# Altium schematic sheet Stage3A_Linear_Regulator_controller.SchDoc
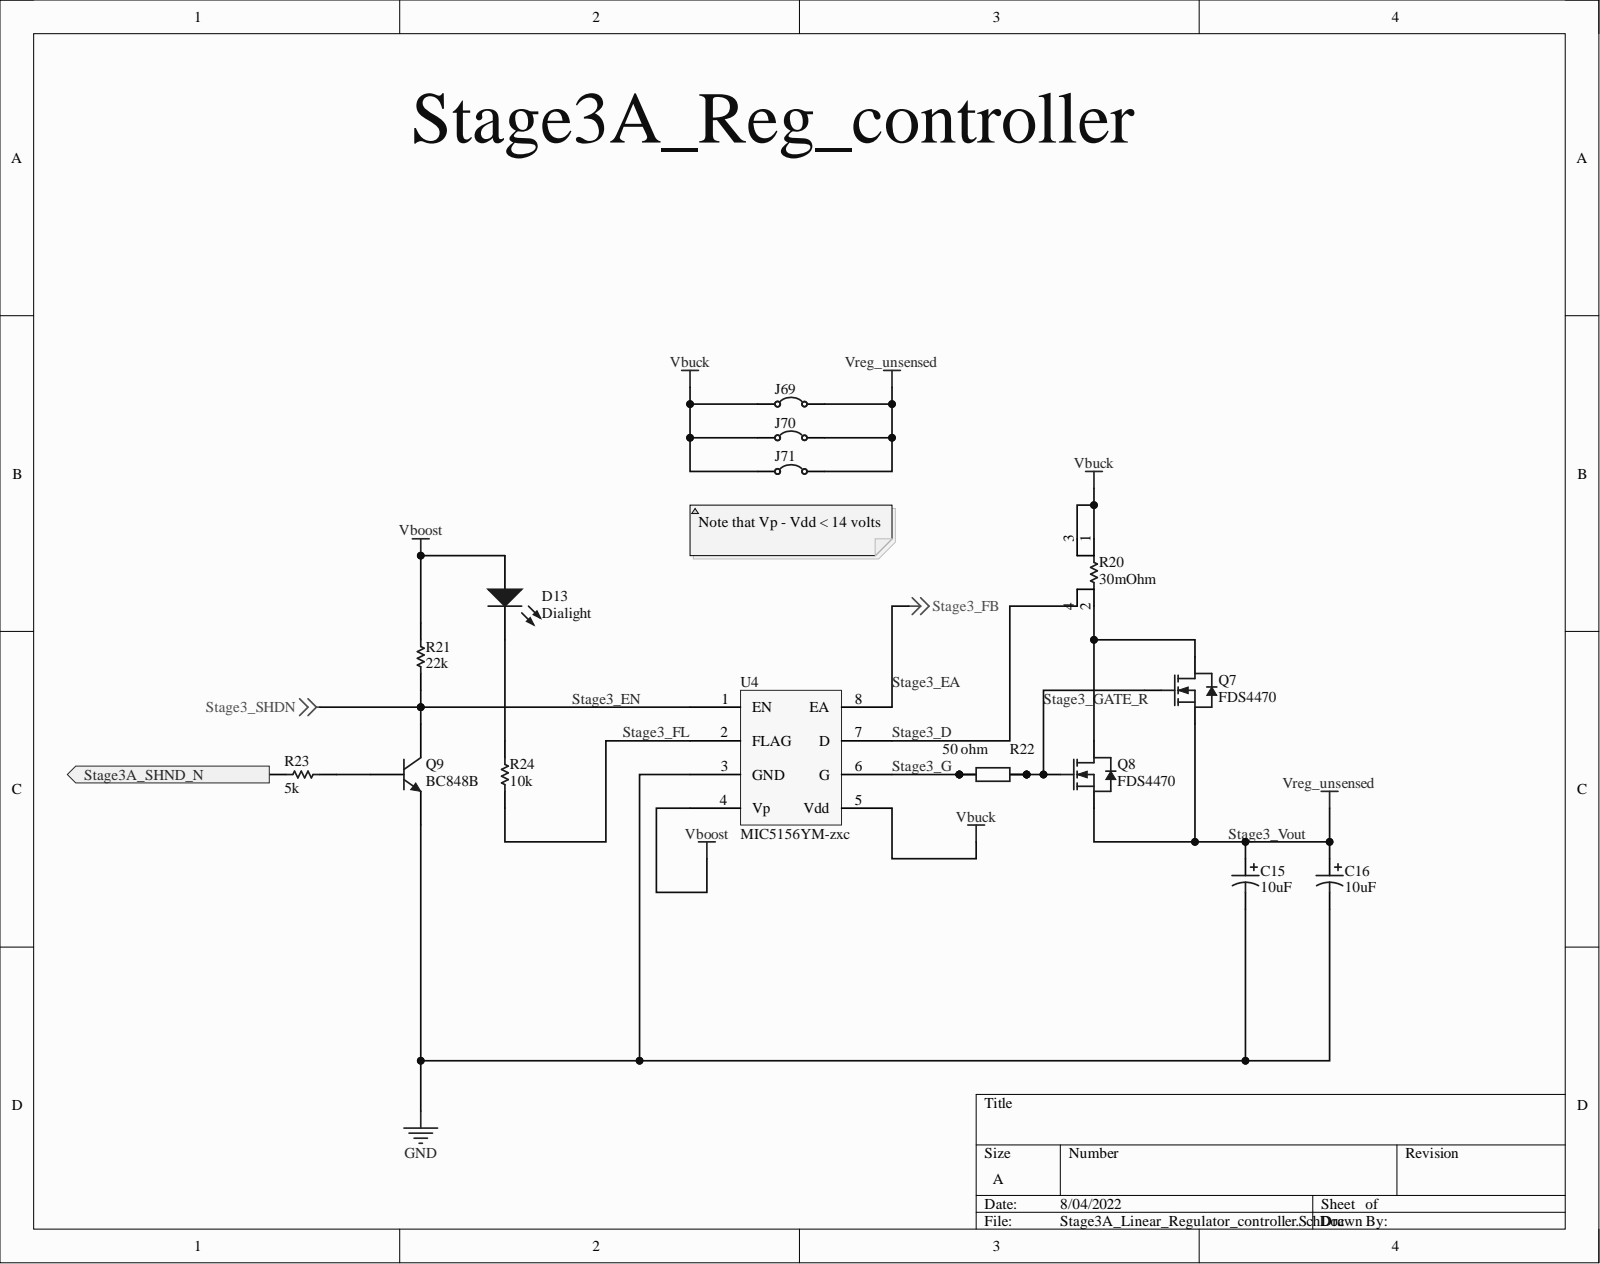
[diagram p0: Stage3A_Linear_Regulator_controller.SchDoc]

## Parts
C15  10uF  [PCB-45uljczbzgwzo9m7ql45-1]
C16  10uF  [PCB-45uljczbzgwzo9m7ql45-1]
D13  Dialight  [PCB-9ifma0oh53wy532w7hco-2]
J69  Harwin S1731-46R  [PCB-70dil207ibnp3ku15xhm-1]  (value not drawn)
J70  Harwin S1731-46R  [PCB-70dil207ibnp3ku15xhm-1]  (value not drawn)
J71  Harwin S1731-46R  [PCB-70dil207ibnp3ku15xhm-1]  (value not drawn)
Q7  FDS4470  [PCB-vam1no1ldi8601k0q85a-1]
Q8  FDS4470  [PCB-vam1no1ldi8601k0q85a-1]
Q9  BC848B  [PCB-hbuntr9r1m1073lqpw4t-1]
R20  30mOhm  [PCB-e4z1ou8eehx9zfei5nig-1]
R21  22k  [PCB-0002-00354-1]
R22  50 ohm  [PCB-7mdwqy8yim8csor4mvd5-1]
R23  5k  [PCB-uip3f7nxj24hdkeeu2m5-1]
R24  10k  [PCB-s0mv190h6t9hazm0rgl3-1]
U4  MIC5156YM-zxc  [D0008A_L]

## Ports
Stage3A_SHND_N

## Nets
GND: C15.2 C16.2 Q9.3 U4.3(GND)
Vboost: D13.2 R21.2 U4.4(Vp)
Vbuck: J69.1 J70.1 J71.1 R20.1 R20.3 U4.5(Vdd)
Stage3_D: R20.4 U4.7(D)
Stage3_EA: U4.8(EA)
Stage3_EN: Q9.1 R21.1 U4.1(EN)
Stage3_FL: R24.1 U4.2(FLAG)
Stage3_G: R22.1 U4.6(G)
Stage3_GATE_R: Q7.1 Q8.1 R22.2
Stage3_Vout: C15.1 C16.1 J69.2 J70.2 J71.2 Q7.2 Q8.2
Stage3A_SHND_N: R23.1 ; port Stage3A_SHND_N
NetD13_1: D13.1 R24.2
NetQ7_3: Q7.3 Q8.3 R20.2
NetQ9_2: Q9.2 R23.2

## Library data of the parts
C15 C16 = CMP-7610bd40367da9d7-6: Capacitor; Aluminum Electrolytic; Fc Radial Elec Cap 10uF 50V; Manufacturer Part Number: EEU-FC1H100L; Capacitance: 10.0 µF; Capacitance Tolerance: ±20%; Lead-Free Status: Lead Free; Voltage Rating (DC): 50.0 V; Component Kind: Standard; Dielectric Material: Aluminum Electrolytic; Library Name: My Personal Space; Lifecycle Status: Not Listed by Manufacturer; Mounting Style: Through Hole; Number of Pins: 2; Octopart URL: https://octopart.com/eeu-fc1h100l-panasonic-39478539; OctopartID: 7610bd40367da9d7; Operating Temperature: 105 °C (max); Packaging: Bulk; REACH SVHC Compliance: No SVHC; RoHS: Compliant; Size-Diameter: 5.00 mm; Size-Height: 11.0 mm; Size-Length: 11.0 mm
D13 = CMP-1071566-10: 598-8110-107F, Dialight, SMD LED 0805 Package, Red Single color SOLD IN INCREMENTS OF 4000. (REEL); Case/Package (SI): 2012; Forward Voltage: 2.20 V; Lead-Free Status: Lead Free; Lens Type: Non-Diffused; Luminous Intensity: 40.0 mcd; Viewing Angle: 140 °; Wavelength: 635 nm, 642 nm; Case/Package: 0805; Color: Red; Component Kind: Standard; Lens Color: Red; Library Name: My Personal Space; Manufacturer Part Number: 598-8110-107F; Mounting Style: Surface Mount, Vertical; Number of Pins: 2; Octopart URL: https://octopart.com/598-8110-107f-dialight-868569; OctopartID: bcc375c4d97c6304; Operating Temperature: 85.0 °C (max); Packaging: Cut Tape (CT); REACH SVHC Compliance: No SVHC; RoHS: Compliant; Size-Height: 700 µm; Size-Length: 2.00 mm; Size-Width: 1.25 mm
J69-J71 = CMP-6cc6a517ff7eb301-1: Jumper (Busbar), Jumper, Pcb Tracks, 2, 6.86 Mm Rohs Compliant: Yes; Component Kind: Standard; Library Name: My Personal Space; Manufacturer Part Number: S1731-46R; Octopart URL: https://octopart.com/s1731-46r-harwin-14399198; OctopartID: 6cc6a517ff7eb301; Packaging: Cut Tape (CT); REACH SVHC Compliance: No SVHC; RoHS: Compliant; Simulation: SIM-nvkkhgunk0p8gq7u5n63-1
Q7 Q8 = CMP-cab003bfccd71577-2: FDS4470 Series 40 V 9 mOhm Surface Mount N-Channel PowerTrench Mosfet - SOIC-8; Breakdown Voltage (Drain to Source): -100.0 V; Continuous Drain Current (Ids): 12.5 A; Drain to Source Resistance (on) (Rds): 0.009 Ω; Drain to Source Voltage (Vds): 40.0 V; Gate Charge: 4.5e-08 C; Input Capacitance: 2.659e-09 F; Lead-Free Status: Lead Free; Power Dissipation: 2.5 W; Rise Time: 1.2e-08 s; Case/Package: SOIC; Component Kind: Standard; Current Rating: 12.5 A; Library Name: My Personal Space; Lifecycle Status: Active; Manufacturer Part Number: FDS4470; Mounting Style: Surface Mount; Number of Pins: 8; Octopart URL: http://octopart.com/fds4470-fairchild+semiconductor-336641; OctopartID: cab003bfccd71577; Packaging: Tape; Polarity: N-Channel; REACH SVHC Compliance: No SVHC; RoHS: Compliant; Voltage Rating (DC): 40.0 V
Q9 = CMP-64f7a94c3727bb30-1: BC848; Manufacturer Part Number: BC848B,215; Lead-Free Status: Lead Free; Polarity: NPN, N-Channel; Case/Package: SOT-23; Component Kind: Standard; Library Name: My Personal Space; Lifecycle Status: Active; Mounting Style: Surface Mount; Number of Pins: 3; Octopart URL: https://octopart.com/bc848b%2C215-nexperia-78739126; OctopartID: 64f7a94c3727bb30; Operating Temperature: -65.0 °C to 150 °C; Packaging: Cut Tape (CT), Tape & Reel (TR); REACH SVHC Compliance: No SVHC; RoHS: Compliant
R20 = CMP-41418819abb09e86-1: Res Metal Alloy 1206 0.03 Ohm 0.5% 0.5W(1/2W) ±50ppm/C Epoxy Resin Pad SMD T/R; Manufacturer Part Number: LVK12R030DER; Case/Package (SI): 3216; Power Rating: 500 mW; Resistance: 30.0 mΩ; Temperature Coefficient: -50.0 ppm/°C to 50.0 ppm/°C; Case/Package: 3216, 1206; Component Kind: Standard; Library Name: My Personal Space; Mounting Style: Surface Mount; Number of Pins: 2; Octopart URL: https://octopart.com/lvk12r030der-ohmite-5427095; OctopartID: 41418819abb09e86; Operating Temperature: -40.0 °C to 125 °C; Packaging: Tape & Reel (TR), Cut Tape (CT); Resistance Tolerance: ±0.5%; RoHS: Compliant
R21 = CMP-661aaa7c9094b9dd-1: Res General Purpose Thick Film 0805 22k Ohm 5% 1/8W ±100ppm/°C Molded Paper T/R; Manufacturer Part Number: CRGCQ0805J22K; Case/Package (SI): 2012; Power Rating: 125 mW; Resistance: 22.0 kΩ; Temperature Coefficient: -100 ppm/°C to 100 ppm/°C; Voltage Rating (DC): 150 V; Case/Package: 2012, 0805; Component Kind: Standard; Library Name: My Personal Space; Lifecycle Status: Not Listed by Manufacturer; Octopart URL: https://octopart.com/crgcq0805j22k-te+connectivity-91018216; OctopartID: 661aaa7c9094b9dd; Packaging: Tape & Reel (TR); Resistance Tolerance: ±5%; RoHS: Compliant
R22 = CMP-c5c70d8b84031a00-2: Res Thick Film 0805 50 Ohm 1% 1/8W ±100ppm/°C Molded SMD Paper T/R; Manufacturer Part Number: CRCW080550R0FKTA; Lead-Free Status: Contains Lead; Power Rating: 125 mW; Resistance: 50.0 Ω; Case/Package: 0805; Component Kind: Standard; Library Name: My Personal Space; Mounting Style: Surface Mount; Octopart URL: https://octopart.com/crcw080550r0fkta-vishay-21390498; OctopartID: c5c70d8b84031a00; Packaging: Reel; Resistance Tolerance: ±1%; RoHS: Non-Compliant
R23 = CMP-68e4a35bf371b723-1: Res Thick Film 0805 0.82 Ohm 5% 0.25W(1/4W) ±200ppm/C Epoxy SMD Automotive T/R; Manufacturer Part Number: RLP73K2AR82JTD; Case/Package (SI): 2012; ELV Compliant: Compliant; Power Rating: 250 mW; Resistance: 820 mΩ; Case/Package: 0805, 2012; Component Kind: Standard; Library Name: My Personal Space; Lifecycle Status: Not Listed by Manufacturer; Mounting Style: Surface Mount; Octopart URL: http://octopart.com/rlp73k2ar82jtd-te+connectivity-45465331; OctopartID: 68e4a35bf371b723; Packaging: Tape & Reel (TR); REACH SVHC Compliance: No SVHC; Resistance Tolerance: ±5%; RoHS: Compliant
R24 = CMP-9c1054914d7443d7-1: Res Thick Film 0805 10K Ohm 1% 1/2W ±200ppm/°C Molded SMD Punched Paper T/R; Manufacturer Part Number: ERJP6WF1002V; Case/Package (SI): 2012; Lead-Free Status: Lead Free; Power Rating: 500 mW; Resistance: 10.0 kΩ; Temperature Coefficient: -200 ppm/°C to 200 ppm/°C; Voltage Rating (DC): 150 V; Case/Package: 2012, 0805; Component Kind: Standard; Library Name: My Personal Space; Lifecycle Status: Not Listed by Manufacturer; Mounting Style: Surface Mount; Octopart URL: https://octopart.com/erjp6wf1002v-panasonic-25949186; OctopartID: 9c1054914d7443d7; Packaging: Tape & Reel (TR), Cut Tape (CT); REACH SVHC Compliance: No SVHC; Resistance Tolerance: ±1%; RoHS: Compliant
U4 = CMP-6f92a5631b83b055-2: MIC5156 Series 1.3 - 36 V ADJ Output Surface Mount Super LDO Regulator - SOIC-8; Lead-Free Status: Lead Free; Case/Package: SOP; Component Kind: Standard; Library Name: My Personal Space; Lifecycle Status: Not Listed by Manufacturer; Manufacturer Part Number: MIC5156YM; Mounting Style: Surface Mount; Number of Pins: 8; Octopart Url: https://octopart.com/mic5156ym-microchip-66802039; OctopartID: 6f92a5631b83b055; Packaging: Cut Tape (CT), Tube; REACH SVHC Compliance: No SVHC; RoHS: Compliant
